# Altium schematic sheet iRat_2.1_Microcontroller.SchDoc
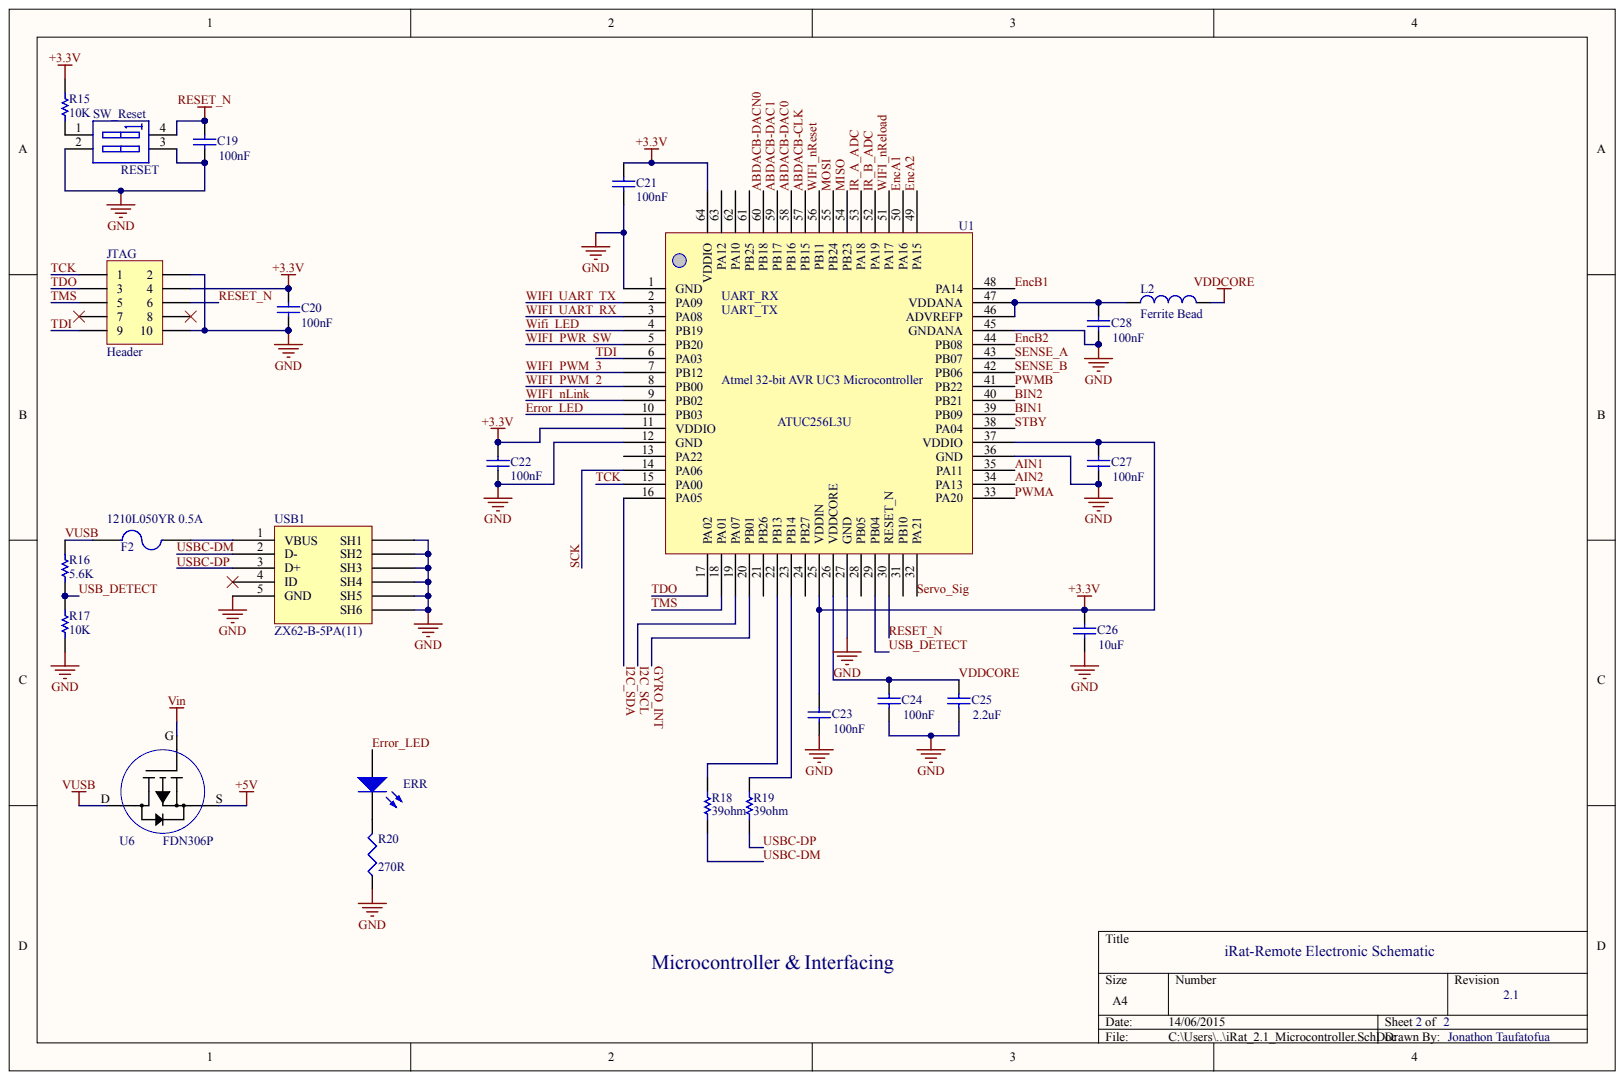
[diagram p0: iRat_2.1_Microcontroller.SchDoc]

## Parts
C19  value 100nF  (hidden comment: Cap Semi)
C20  value 100nF  (hidden comment: Cap Semi)
C21  value 100nF  (hidden comment: Cap Semi)
C22  value 100nF  (hidden comment: Cap Semi)
C23  value 100nF  (hidden comment: Cap Semi)
C24  value 100nF  (hidden comment: Cap Semi)
C25  value 2.2uF  (hidden comment: Cap Semi)
C26  value 10uF
C27  value 100nF  (hidden comment: Cap Semi)
C28  value 100nF  (hidden comment: Cap Semi)
ERR  LNJ347W83RA  (value not drawn)
F2  1210L050YR 0.5A
JTAG  Header
L2  Ferrite Bead
R15  value 10K
R16  value 5.6K  (hidden comment: Res Semi)
R17  value 10K  (hidden comment: Res Semi)
R18  value 39ohm  (hidden comment: Res1)
R19  value 39ohm  (hidden comment: Res1)
R20  value 270R  (hidden comment: Res3)
SW_Reset  RESET
U1  ATUC256L3U-AUT  (value not drawn)
U6  FDN306P
USB1  ZX62-B-5PA(11)

## Nets
+3.3V: C20.1 C21.2 C22.2 C23.2 C26.2 C27.2 JTAG.4 R15.1 U1.11(VDDIO) U1.25(VDDIN) U1.37(VDDIO) U1.64(VDDIO)
+5V: U6.S
GND: C19.1 C20.2 C21.1 C22.1 C23.1 C24.1 C25.1 C26.1 C27.1 C28.1 JTAG.2 JTAG.10 R17.1 R20.1 SW_Reset.2 SW_Reset.3 U1.1(GND) U1.12(GND) U1.27(GND) U1.36(GND) U1.45(GNDANA) USB1.5(GND) USB1.SH1 USB1.SH2 USB1.SH3 USB1.SH4 USB1.SH5 USB1.SH6
VIN: U6.G
ABDACB-CLK: U1.57(PB15)
ABDACB-DAC0: U1.58(PB16)
ABDACB-DAC1: U1.59(PB17)
ABDACB-DACN0: U1.60(PB18)
AIN1: U1.35(PA11)
AIN2: U1.34(PA13)
BIN1: U1.39(PB09)
BIN2: U1.40(PB21)
ENCA1: U1.50(PA16)
ENCA2: U1.49(PA15)
ENCB1: U1.48(PA14)
ENCB2: U1.44(PB08)
ERROR_LED: ERR.1 U1.10(PB03)
GYRO_INT: U1.20(PB01)
I2C_SCL: U1.19(PA07)
I2C_SDA: U1.16(PA05)
IR_A_ADC: U1.53(PA18)
IR_B_ADC: U1.52(PA19)
MISO: U1.54(PB23)
MOSI: U1.55(PB24)
PWMA: U1.33(PA20)
PWMB: U1.41(PB22)
RESET_N: C19.2 JTAG.6 SW_Reset.4 U1.30(RESET_N)
SCK: U1.14(PA06)
SENSE_A: U1.43(PB07)
SENSE_B: U1.42(PB06)
SERVO_SIG: U1.32(PA21)
STBY: U1.38(PA04)
TCK: JTAG.1 U1.15(PA00)
TDI: JTAG.9 U1.6(PA03)
TDO: JTAG.3 U1.17(PA02)
TMS: JTAG.5 U1.18(PA01)
USB_DETECT: R16.1 R17.2 U1.29(PB04)
USBC-DM: R18.2 USB1.2(D-)
USBC-DP: R19.2 USB1.3(D+)
VDDCORE: C24.2 C25.2 L2.2 U1.26(VDDCORE)
VUSB: F2.2 R16.2 U6.D
WIFI_LED: U1.4(PB19)
WIFI_NLINK: U1.9(PB02)
WIFI_NRELOAD: U1.51(PA17)
WIFI_NRESET: U1.56(PB11)
WIFI_PWM_2: U1.8(PB00)
WIFI_PWM_3: U1.7(PB12)
WIFI_PWR_SW: U1.5(PB20)
WIFI_UART_RX: U1.3(PA08)
WIFI_UART_TX: U1.2(PA09)
NetC28_2: C28.2 L2.1 U1.46(ADVREFP) U1.47(VDDANA)
NetERR_2: ERR.2 R20.2
NetF2_1: F2.1 USB1.1(VBUS)
NetR15_2: R15.2 SW_Reset.1
NetR18_1: R18.1 U1.22(PB13)
NetR19_1: R19.1 U1.23(PB14)

Not connected: JTAG 7 8; U1 13(PA22) 21(PB26) 24(PB27) 28(PB05) 31(PB10) 61(PB25) 62(PA10) 63(PA12); USB1 4(ID)

## Library data of the parts
L2 = (no symbol name): Code_IEC: 1005; Published: 8-Jun-2000; LatestRevisionDate: 29-May-2009; LatestRevisionNote: IPC-7351 Footprint Added.; PackageReference: 0402-A; PackageDescription: Chip Inductor; Code_JEITA: 0402; Publisher: Altium Limited
C19-C28 ERR F2 JTAG R15-R20 SW_Reset U1 U6 USB1 = (no symbol name)
  C19-C28: Published: 8-Jun-2000; LatestRevisionDate: 29-May-2009; LatestRevisionNote: IPC-7351 Footprint Added.; PackageReference: C1206; PackageDescription: Chip Capacitor
  ERR: Published: 8-Jun-2000; LatestRevisionDate: 17-Jul-2002; LatestRevisionNote: Re-released for DXP Platform.; PackageVersion: Feb-2002; PackageReference: 3.2X1.6X1.1; PackageDescription: SM LED; 2 Flat Leads
  F2: Published: 8-Jun-2000; LatestRevisionDate: 17-Jul-2002; LatestRevisionNote: Re-released for DXP Platform.; PackageReference: PIN-W2/E2.8; PackageDescription: Thru-Hole; 2 Leads
  JTAG: LatestRevisionDate: 17-Jul-2002; LatestRevisionNote: Re-released for DXP Platform.
  R15-R17: Published: 8-Jun-2000; LatestRevisionDate: 17-Jul-2002; LatestRevisionNote: Re-released for DXP Platform.; PackageReference: AXIAL-0.5; PackageDescription: Axial Device, Thru-Hole; 2 Leads; 0.5 in Pin Spacing
  R18 R19: Published: 8-Jun-2000; LatestRevisionDate: 17-Jul-2002; LatestRevisionNote: Re-released for DXP Platform.; PackageReference: AXIAL-0.3; PackageDescription: Axial Device, Thru-Hole; 2 Leads; 0.3 in Pin Spacing
  R20: Published: 8-Jun-2000; LatestRevisionDate: 29-May-2009; LatestRevisionNote: IPC-7351 Footprint Added.; PackageReference: J1-0603; PackageDescription: Chip Resistor
  SW_Reset: Published: 24-Mar-1999; LatestRevisionDate: 17-Jul-2002; LatestRevisionNote: Re-released for DXP Platform.; PackageReference: DIP-4
  C19-C28 ERR F2 JTAG R15-R20 SW_Reset: Publisher: Altium Limited
